# Altium schematic sheet Schematics_HUMA-mini.SchDoc
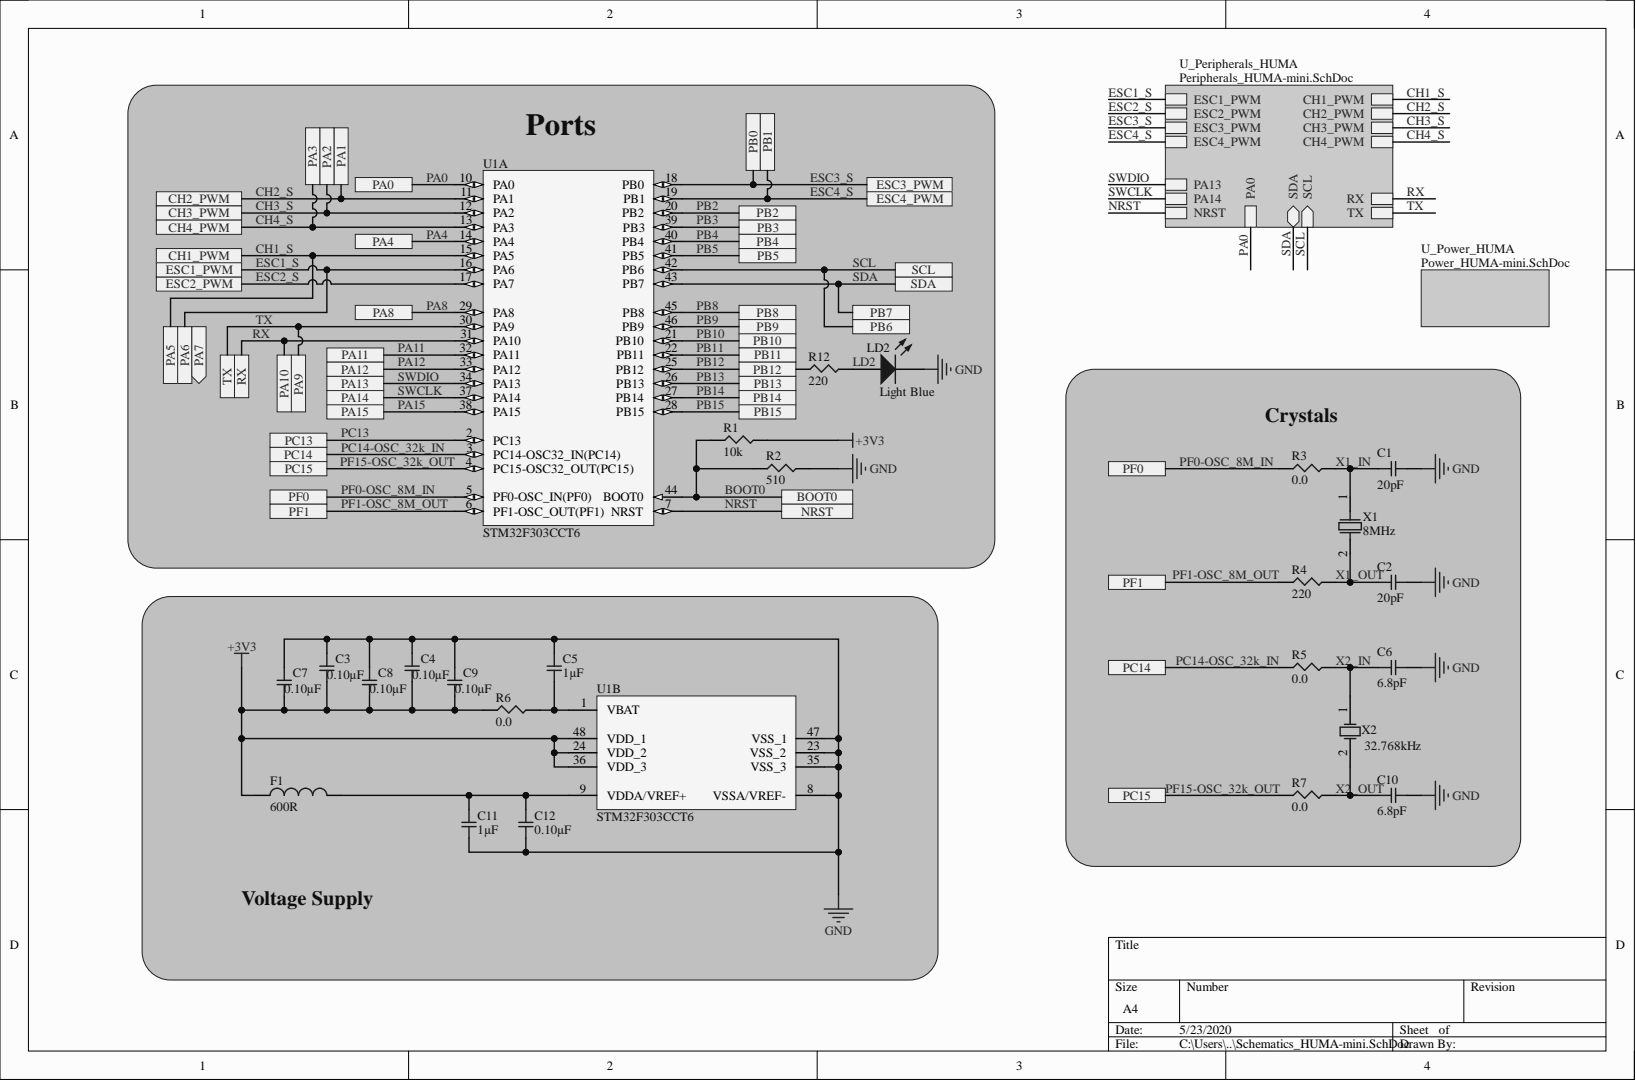
[diagram p0: Schematics_HUMA-mini.SchDoc]

## Parts
C1  20pF  [SMD-0805C]
C2  20pF  [SMD-0805C]
C3  0.10µF  [SMD-0805C]
C4  0.10µF  [SMD-0805C]
C5  1µF  [SMD-0805C]
C6  6.8pF  [SMD-0805C]
C7  0.10µF  [SMD-0805C]
C8  0.10µF  [SMD-0805C]
C9  0.10µF  [SMD-0805C]
C10  6.8pF  [SMD-0805C]
C11  1µF  [SMD-0805C]
C12  0.10µF  [SMD-0805C]
F1  Deger: 600R  [IND_0805]  (hidden comment: FERRITE BEAD 600 OHM 1206 1LN)
LD2  Light Blue  Emitting color: Blue  [Type 3mm]
R1  10k  [SMD-0805-RES]
R2  510  [SMD-0805-RES]
R3  0.0  [SMD-0805-RES]
R4  220  [SMD-0805-RES]
R5  0.0  [SMD-0805-RES]
R6  0.0  [SMD-0805-RES]
R7  0.0  [SMD-0805-RES]
R12  220  [SMD-0805-RES]
U1  STM32F303CCT6  [STM-LQFP48_N]  (parts A,B)
X1  8MHz  [OSC488W43P488L465H350Q2P]
X2  value 32.768kHz  [R38]  (hidden comment: XTAL)

## Ports
BOOT0, CH1_PWM, CH2_PWM, CH3_PWM, CH4_PWM, ESC1_PWM, ESC2_PWM, ESC3_PWM, ESC4_PWM, NRST, PA0, PA1, PA2, PA3, PA4, PA5, PA6, PA7, PA8, PA9, PA10, PA11, PA12, PA13, PA14, PA15, PB0, PB1, PB2, PB3, PB4, PB5, PB6, PB7, PB8, PB9, PB10, PB11, PB12, PB13, PB14, PB15, PC13, PC14, PC15, PF0, PF1, RX, SCL, SDA, TX

## Nets
+3V3: C3.2 C4.2 C7.2 C8.2 C9.2 F1.1 R1.2 R6.1 U1.24(VDD_2) U1.36(VDD_3) U1.48(VDD_1)
GND: C1.2 C2.2 C3.1 C4.1 C5.1 C6.2 C7.1 C8.1 C9.1 C10.2 C11.2 C12.2 LD2.1 R2.2 U1.8(VSSA/VREF-) U1.23(VSS_2) U1.35(VSS_3) U1.47(VSS_1)
BOOT0: R1.1 R2.1 U1.44(BOOT0) ; port BOOT0
CH1_S: U1.15(PA5) ; port CH1_PWM, port PA5
CH2_S: U1.11(PA1) ; port CH2_PWM, port PA1
CH3_S: U1.12(PA2) ; port CH3_PWM, port PA2
CH4_S: U1.13(PA3) ; port CH4_PWM, port PA3
ESC1_S: U1.16(PA6) ; port ESC1_PWM, port PA6
ESC2_S: U1.17(PA7) ; port ESC2_PWM
ESC3_S: U1.18(PB0) ; port ESC3_PWM, port PB0
ESC4_S: U1.19(PB1) ; port ESC4_PWM, port PB1
LD2: LD2.2 R12.2
NRST: U1.7(NRST) ; port NRST
PA0: U1.10(PA0) ; port PA0
PA4: U1.14(PA4) ; port PA4
PA8: U1.29(PA8) ; port PA8
PA11: U1.32(PA11) ; port PA11
PA12: U1.33(PA12) ; port PA12
PA15: U1.38(PA15) ; port PA15
PB2: U1.20(PB2) ; port PB2
PB3: U1.39(PB3) ; port PB3
PB4: U1.40(PB4) ; port PB4
PB5: U1.41(PB5) ; port PB5
PB8: U1.45(PB8) ; port PB8
PB9: U1.46(PB9) ; port PB9
PB10: U1.21(PB10) ; port PB10
PB11: U1.22(PB11) ; port PB11
PB12: R12.1 U1.25(PB12) ; port PB12
PB13: U1.26(PB13) ; port PB13
PB14: U1.27(PB14) ; port PB14
PB15: U1.28(PB15) ; port PB15
PC13: U1.2(PC13) ; port PC13
PC14-OSC_32k_IN: R5.1 U1.3(PC14-OSC32_IN(PC14)) ; port PC14
PF0-OSC_8M_IN: R3.1 U1.5(PF0-OSC_IN(PF0)) ; port PF0
PF1-OSC_8M_OUT: R4.1 U1.6(PF1-OSC_OUT(PF1)) ; port PF1
PF15-OSC_32k_OUT: R7.1 U1.4(PC15-OSC32_OUT(PC15)) ; port PC15
RX: U1.31(PA10) ; port PA10, port RX
SCL: U1.42(PB6) ; port PB6, port SCL
SDA: U1.43(PB7) ; port PB7, port SDA
SWCLK: U1.37(PA14) ; port PA14
SWDIO: U1.34(PA13) ; port PA13
TX: U1.30(PA9) ; port PA9, port TX
X1_IN: C1.1 R3.2 X1.1
X1_OUT: C2.1 R4.2 X1.2
X2_IN: C6.1 R5.2 X2.1
X2_OUT: C10.1 R7.2 X2.2
NetC5_2: C5.2 R6.2 U1.1(VBAT)
NetC11_1: C11.1 C12.1 F1.2 U1.9(VDDA/VREF+)
N00001: ; port PA7

## Library data of the parts
C1 C2 = GQM2195C1H200JB01D: CAP CER 20PF 50V NP0 0805; Manufacturer: Murata Electronics North America; @ qty: 0; Minimum Quantity: 1; Temperature Coefficient: C0G, NP0; Tolerance: ±5%; Unit Price (USD): 0.78; Applications: RF, Microwave, High Frequency; Component Kind: Standard; Datasheets: http://www.murata.com/~/media/webrenewal/support/library/catalog/products/capacitor/mlcc/c02e.pdf; Digi-Key Part Number: 490-3607-1-ND; Factory Stock: 0; Features: High Q, Low Loss; Height - Seated (Max): -; Image: //media.digikey.com/Renders/Murata%20Renders/0805(GxM9).jpg; Lead Spacing: -; Lead Style: -; Library Name: Capacitors_Murata.IntLib; Mounting Type: Surface Mount, MLCC; Operating Temperature: -55°C ~ 125°C; Package / Case: 0805 (2012 Metric); Packaging: Cut Tape (CT); Part Number: GQM2195C1H200JB01D; Part Status: Active; Quantity Available: 270; Ratings: -; Series: GQM; Size / Dimension: 0.079" L x 0.049" W (2.00mm x 1.25mm); Thickness (Max): 0.037" (0.95mm); Value: 20pF; Voltage: 50V
C3 C4 C7-C9 C12 = GRM219R71E104KA01D: CAP CER 0.1UF 25V X7R 0805; Manufacturer: Murata Electronics North America; @ qty: 0; Minimum Quantity: 1; Tolerance: ±10%; Unit Price (USD): 0.12; Applications: General Purpose; Component Kind: Standard; Datasheets: http://www.murata.com/~/media/webrenewal/support/library/catalog/products/capacitor/mlcc/c02e.pdf; Digi-Key Part Number: 490-12754-1-ND; Factory Stock: 0; Features: -; Height - Seated (Max): -; Image: //media.digikey.com/Renders/Murata%20Renders/0805%20(2012%20Metric)%20.85mm.jpg; Lead Spacing: -; Lead Style: -; Library Name: Capacitors_Murata.IntLib; Mounting Type: Surface Mount, MLCC; Operating Temperature: -55°C ~ 125°C; Package / Case: 0805 (2012 Metric); Packaging: Cut Tape (CT); Part Number: GRM219R71E104KA01D; Part Status: Active; Quantity Available: 8594; Ratings: -; Series: GRM; Size / Dimension: 0.079" L x 0.049" W (2.00mm x 1.25mm); Temperature Coefficient: X7R; Thickness (Max): 0.037" (0.95mm); Value: 0.10µF; Voltage: 25V
C5 C11 = GRT21BR61E105KE13L: CAP CER 1UF 25V X5R 0805; Manufacturer: Murata Electronics North America; @ qty: 0; Minimum Quantity: 1; Tolerance: ±10%; Unit Price (USD): 0.2; Applications: Automotive; Component Kind: Standard; Datasheets: http://search.murata.co.jp/Ceramy/image/img/A01X/G101/ENG/GRT21BR61E105KE13-01.pdf; Digi-Key Part Number: 490-12386-1-ND; Factory Stock: 0; Features: -; Height - Seated (Max): -; Image: //media.digikey.com/Renders/Murata%20Renders/GRT%20Series%200805(2012%20Metric).jpg; Lead Spacing: -; Lead Style: -; Library Name: Capacitors_Murata.IntLib; Mounting Type: Surface Mount, MLCC; Operating Temperature: -55°C ~ 85°C; Package / Case: 0805 (2012 Metric); Packaging: Cut Tape (CT); Part Number: GRT21BR61E105KE13L; Part Status: Active; Quantity Available: 2725; Ratings: AEC-Q200; Series: GRT; Size / Dimension: 0.079" L x 0.049" W (2.00mm x 1.25mm); Temperature Coefficient: X5R; Thickness (Max): 0.057" (1.45mm); Value: 1µF; Voltage: 25V
C6 C10 = GQM2195C2A6R8DB01D: CAP CER 6.8PF 100V NP0 0805; Manufacturer: Murata Electronics North America; @ qty: 0; Minimum Quantity: 1; Temperature Coefficient: C0G, NP0; Tolerance: ±0.5pF; Unit Price (USD): 0.71; Applications: RF, Microwave, High Frequency; Component Kind: Standard; Datasheets: http://www.murata.com/~/media/webrenewal/support/library/catalog/products/capacitor/mlcc/c02e.pdf; Digi-Key Part Number: 490-8142-1-ND; Factory Stock: 0; Features: High Q, Low Loss; Height - Seated (Max): -; Image: //media.digikey.com/Renders/Murata%20Renders/0805(GxM9).jpg; Lead Spacing: -; Lead Style: -; Library Name: Capacitors_Murata.IntLib; Mounting Type: Surface Mount, MLCC; Operating Temperature: -55°C ~ 125°C; Package / Case: 0805 (2012 Metric); Packaging: Cut Tape (CT); Part Number: GQM2195C2A6R8DB01D; Part Status: Active; Quantity Available: 3756; Ratings: -; Series: GQM; Size / Dimension: 0.079" L x 0.049" W (2.00mm x 1.25mm); Thickness (Max): 0.037" (0.95mm); Value: 6.8pF; Voltage: 100V
F1 = Ferrite 600ohm 1206(742792118): 600 Ohms @ 100MHz 1 Signal Line Ferrite Bead 1206 (3216 Metric) 2.5A 70mOhm; +Sicaklik: +125; -Sicaklik: -55; Epoksi Bilgisi: YOK; Kaplama Bilgisi: UYGUN; Kılıf: 1206; Lehim Yöntemi: SMD; Nero Kodu: NE-S-02777; Cins: Ferrite; Component Kind: Standard; MSL: 1 (Unlimited); Uretici Kodu: 742792118; Yıkama Bilgisi: UYGUN; Library Name: Bobin-Ferit.SchLib
LD2 = 151033BS03000: THT LED round mono-color waterclear, WL-TMRW , Blue; Component Kind: Standard; ComponentLink1Description: Manufacturer URL; ComponentLink1URL: http://www.we-online.com; ComponentLink2Description: Datasheet; ComponentLink2URL: http://katalog.we-online.de/pbs/datasheet/7448042001.pdfhttp://katalog.we-online.de/pbs/datasheet/7448042001.pdfhttp://katalog.we-online.de/pbs/datasheet/7448042001.pdfhttp://katalog.we-online.de/pbs/datasheet/151033BS03000.pdf; Library Name: WL-TMRW THT LED round mono-color waterclear.IntLib; PackageReference: Type 3mm; PartNumber: 151033BS03000
R1 = ERJ-6ENF1002V: RES SMD 10K OHM 1% 1/8W 0805; Manufacturer: Panasonic Electronic Components; @ qty: 1500; Factory Stock: 0; Minimum Quantity: 1; Power (Watts): 0.125W, 1/8W; Temperature Coefficient: ±100ppm/°C; Unit Price (USD): 0.00729; Component Kind: Standard; Composition: Thick Film; Datasheets: http://industrial.panasonic.com/www-cgi/jvcr13pz.cgi?E+PZ+3+AOA0002+ERJ6ENF1002V+7+WW; Digi-Key Part Number: P10.0KCCT-ND; Failure Rate: -; Features: Automotive AEC-Q200; Height - Seated (Max): 0.028" (0.70mm); Image: //media.digikey.com/Renders/Panasonic%20Renders/ERJ-0805%20Pkg.jpg; Library Name: Resistors_Panasonic.IntLib; Number of Terminations: 2; Operating Temperature: -55°C ~ 155°C; Package / Case: 0805 (2012 Metric); Packaging: Cut Tape (CT); Part Number: ERJ-6ENF1002V; Part Status: Active; Quantity Available: 3985614; Series: ERJ; Size / Dimension: 0.079" L x 0.049" W (2.00mm x 1.25mm); Supplier Device Package: 0805; Tolerance: ±1%; Value: 10k
R2 = ERJ-P06J511V: RES SMD 510 OHM 5% 1/2W 0805; Manufacturer: Panasonic Electronic Components; @ qty: 1500; Factory Stock: 0; Minimum Quantity: 1; Power (Watts): 0.5W, 1/2W; Temperature Coefficient: ±200ppm/°C; Unit Price (USD): 0.01839; Component Kind: Standard; Composition: Thick Film; Datasheets: https://industrial.panasonic.com/cdbs/www-data/pdf/RDA0000/AOA0000C243.pdf; Digi-Key Part Number: P510ADCT-ND; Failure Rate: -; Features: Automotive AEC-Q200, Pulse Withstanding; Height - Seated (Max): 0.028" (0.70mm); Image: //media.digikey.com/Renders/Panasonic%20Renders/ERJ-0805%20Pkg.jpg; Library Name: Resistors_Panasonic.IntLib; Number of Terminations: 2; Operating Temperature: -55°C ~ 155°C; Package / Case: 0805 (2012 Metric); Packaging: Cut Tape (CT); Part Number: ERJ-P06J511V; Part Status: Active; Quantity Available: 54515; Series: ERJ; Size / Dimension: 0.079" L x 0.049" W (2.00mm x 1.25mm); Supplier Device Package: 0805; Tolerance: ±5%; Value: 510
R3 R5-R7 = ERJ-6GEY0R00V: RES SMD 0.0 OHM JUMPER 1/8W 0805; Manufacturer: Panasonic Electronic Components; @ qty: 1500; Factory Stock: 0; Minimum Quantity: 1; Power (Watts): 0.125W, 1/8W; Unit Price (USD): 0.00544; Component Kind: Standard; Composition: Thick Film; Datasheets: http://industrial.panasonic.com/www-cgi/jvcr13pz.cgi?E+PZ+3+AOA0001+ERJ6GEY0R00V+7+WW; Digi-Key Part Number: P0.0ACT-ND; Failure Rate: -; Features: Automotive AEC-Q200; Height - Seated (Max): 0.028" (0.70mm); Image: //media.digikey.com/Renders/Panasonic%20Renders/ERJ-0805%20Pkg.jpg; Library Name: Resistors_Panasonic.IntLib; Number of Terminations: 2; Operating Temperature: -55°C ~ 155°C; Package / Case: 0805 (2012 Metric); Packaging: Cut Tape (CT); Part Number: ERJ-6GEY0R00V; Part Status: Active; Quantity Available: 1597627; Series: ERJ; Size / Dimension: 0.079" L x 0.049" W (2.00mm x 1.25mm); Supplier Device Package: 0805; Temperature Coefficient: -; Tolerance: Jumper; Value: 0.0
R4 = ERJ-6ENF2200V: RES SMD 220 OHM 1% 1/8W 0805; Manufacturer: Panasonic Electronic Components; @ qty: 1500; Factory Stock: 0; Minimum Quantity: 1; Power (Watts): 0.125W, 1/8W; Temperature Coefficient: ±100ppm/°C; Unit Price (USD): 0.00729; Component Kind: Standard; Composition: Thick Film; Datasheets: http://industrial.panasonic.com/www-cgi/jvcr13pz.cgi?E+PZ+3+AOA0002+ERJ6ENF2200V+7+WW; Digi-Key Part Number: P220CCT-ND; Failure Rate: -; Features: Automotive AEC-Q200; Height - Seated (Max): 0.028" (0.70mm); Image: //media.digikey.com/Renders/Panasonic%20Renders/ERJ-0805%20Pkg.jpg; Library Name: Resistors_Panasonic.IntLib; Number of Terminations: 2; Operating Temperature: -55°C ~ 155°C; Package / Case: 0805 (2012 Metric); Packaging: Cut Tape (CT); Part Number: ERJ-6ENF2200V; Part Status: Active; Quantity Available: 111570; Series: ERJ; Size / Dimension: 0.079" L x 0.049" W (2.00mm x 1.25mm); Supplier Device Package: 0805; Tolerance: ±1%; Value: 220
R12 = ERJ-P6WJ221V: RES SMD 220 OHM 5% 1/2W 0805; Manufacturer: Panasonic Electronic Components; @ qty: 1500; Factory Stock: 0; Minimum Quantity: 1; Power (Watts): 0.5W, 1/2W; Temperature Coefficient: ±200ppm/°C; Unit Price (USD): 0.08237; Component Kind: Standard; Composition: Thick Film; Datasheets: https://industrial.panasonic.com/cdbs/www-data/pdf/RDA0000/AOA0000C243.pdf; Digi-Key Part Number: P16946CT-ND; Failure Rate: -; Features: Automotive AEC-Q200, Pulse Withstanding; Height - Seated (Max): 0.030" (0.75mm); Image: //media.digikey.com/Renders/Panasonic%20Renders/ERJ-0805%20Pkg.jpg; Library Name: Resistors_Panasonic.IntLib; Number of Terminations: 2; Operating Temperature: -55°C ~ 155°C; Package / Case: 0805 (2012 Metric); Packaging: Cut Tape (CT); Part Number: ERJ-P6WJ221V; Part Status: Active; Quantity Available: 4990; Series: ERJ; Size / Dimension: 0.079" L x 0.049" W (2.00mm x 1.25mm); Supplier Device Package: 0805; Tolerance: ±5%; Value: 220
U1 = STM32F303CCT6: ARM Cortex-M4 32-bit MCU, 256 KB Flash, 40 KB Internal RAM, 37 I/Os, 48-pin LQFP, -40 to 85 degC, Tray; D/A Converter: 2x12-bit (2 Channel); FLASH Size (Prog) (kB): 256; I/Os (High Current): 37; Internal RAM Size (kB): 40; Operating Frequency(F) (Processor speed) (MHz): 72; Supply Voltage(Vcc) min (V): 2; Component Kind: Standard; ComponentLink1Description: Manufacturer URL; ComponentLink1URL: http://www.st.com/web/en/home.html; ComponentLink2Description: Datasheet; ComponentLink2URL: http://www.st.com/st-web-ui/static/active/en/resource/technical/document/datasheet/DM00058181.pdf; ComponentLink3Description: Package Specification; ComponentLink3URL: http://www.st.com/st-web-ui/static/active/en/resource/technical/document/datasheet/DM00058181.pdf; Core: ARM Cortex-M4; DatasheetVersion: Rev. 6; Library Name: STMicroelectronics STM32 F3.IntLib; Mounting Technology: Surface Mount; PackageDescription: 48-Pin Low Profile Quad Flat Package, 7 x 7 mm Body, 0.5 mm Pitch; PackageReference: LQFP48; PackageVersion: Rev. 6, 06/2013; Packing: Tray; PartNumber: STM32F303CCT6; Supplier 1: Digi-Key; Supplier 2: Newark; Supplier 3: Newark; Supplier 4: Mouser; Supplier Part Number 1: 497-13318-ND; Supplier Part Number 2: 46W2192; Supplier Part Number 3: 66W7286; Supplier Part Number 4: 511-STM32F303CCT6
X1 = XTAL8MHz: Crystal; Frequency: 8MHz; Frequency; MF: AEL Crystals; MP: X14M745600L225; Availability: Unavailable; Component Kind: Standard; Library Name: XTAL8MHz.IntLib; Package: None; Price: None; SnapEDA_Link: https://www.snapeda.com/parts/X14M745600L225/AEL%20Crystals/view-part/380339/?ref=snap
X2 = XTAL: Crystal Oscillator; Component Kind: Standard; LatestRevisionDate: 17-Jul-2002; LatestRevisionNote: Re-released for DXP Platform.; Library Name: Miscellaneous Devices.IntLib; PackageDescription: Crystal, Thru-Hole; 2 Leads; Body 3.1 x 8.2 mm (Dia.xH); PackageReference: R38; Published: 8-Jun-2000; Simulation: XTAL; Publisher: Altium Limited
